# Altium schematic sheet sensors.SchDoc(sensors)
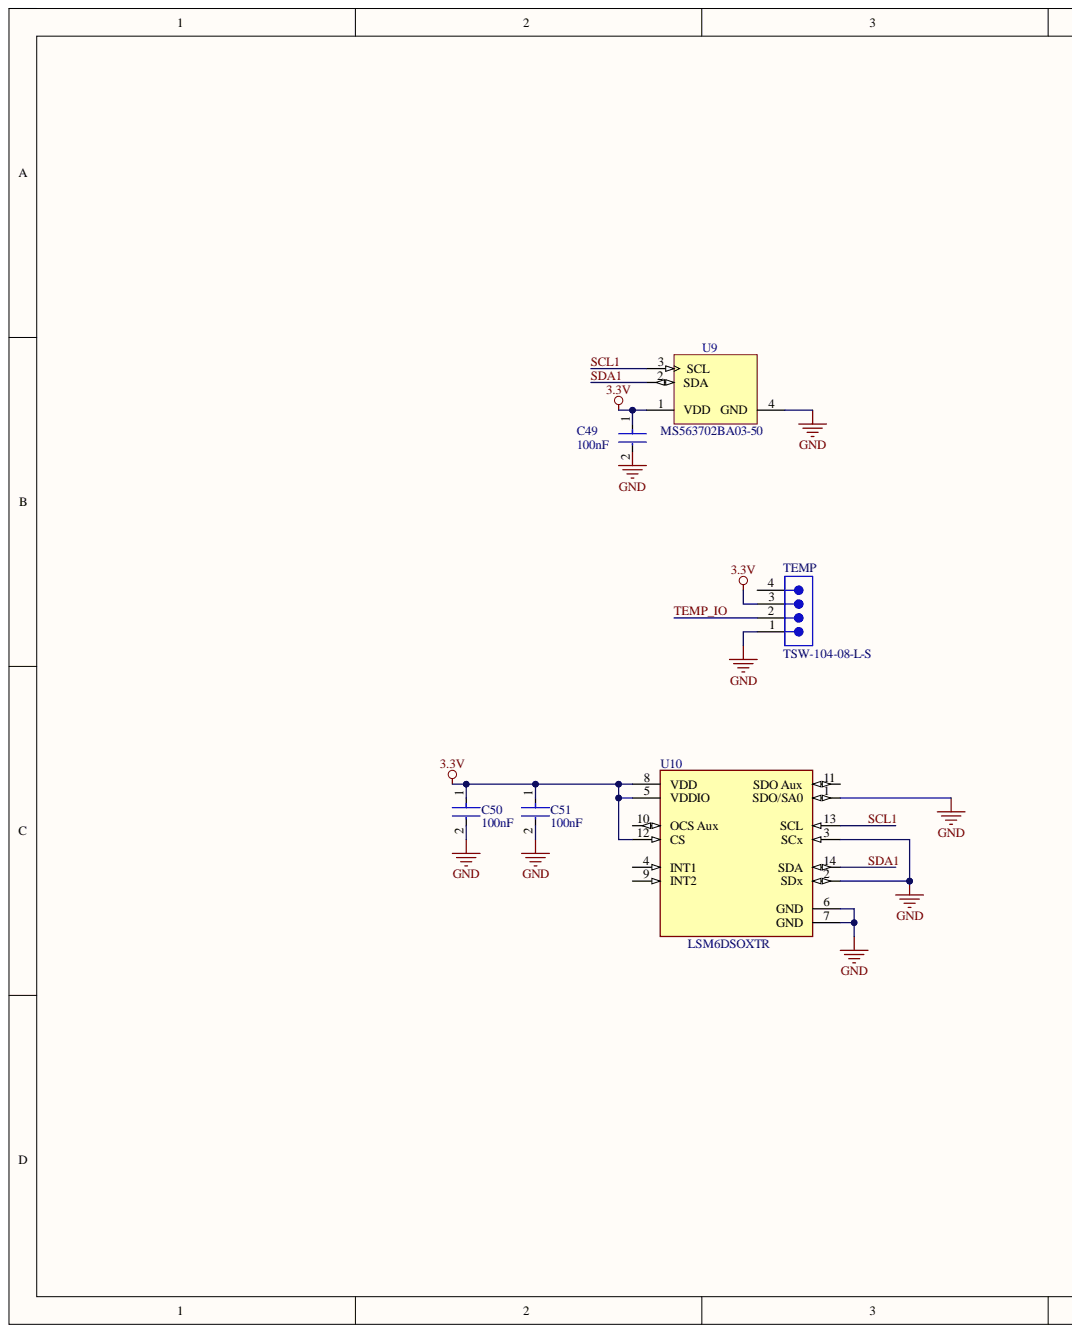
[diagram p0: sensors.SchDoc, left]
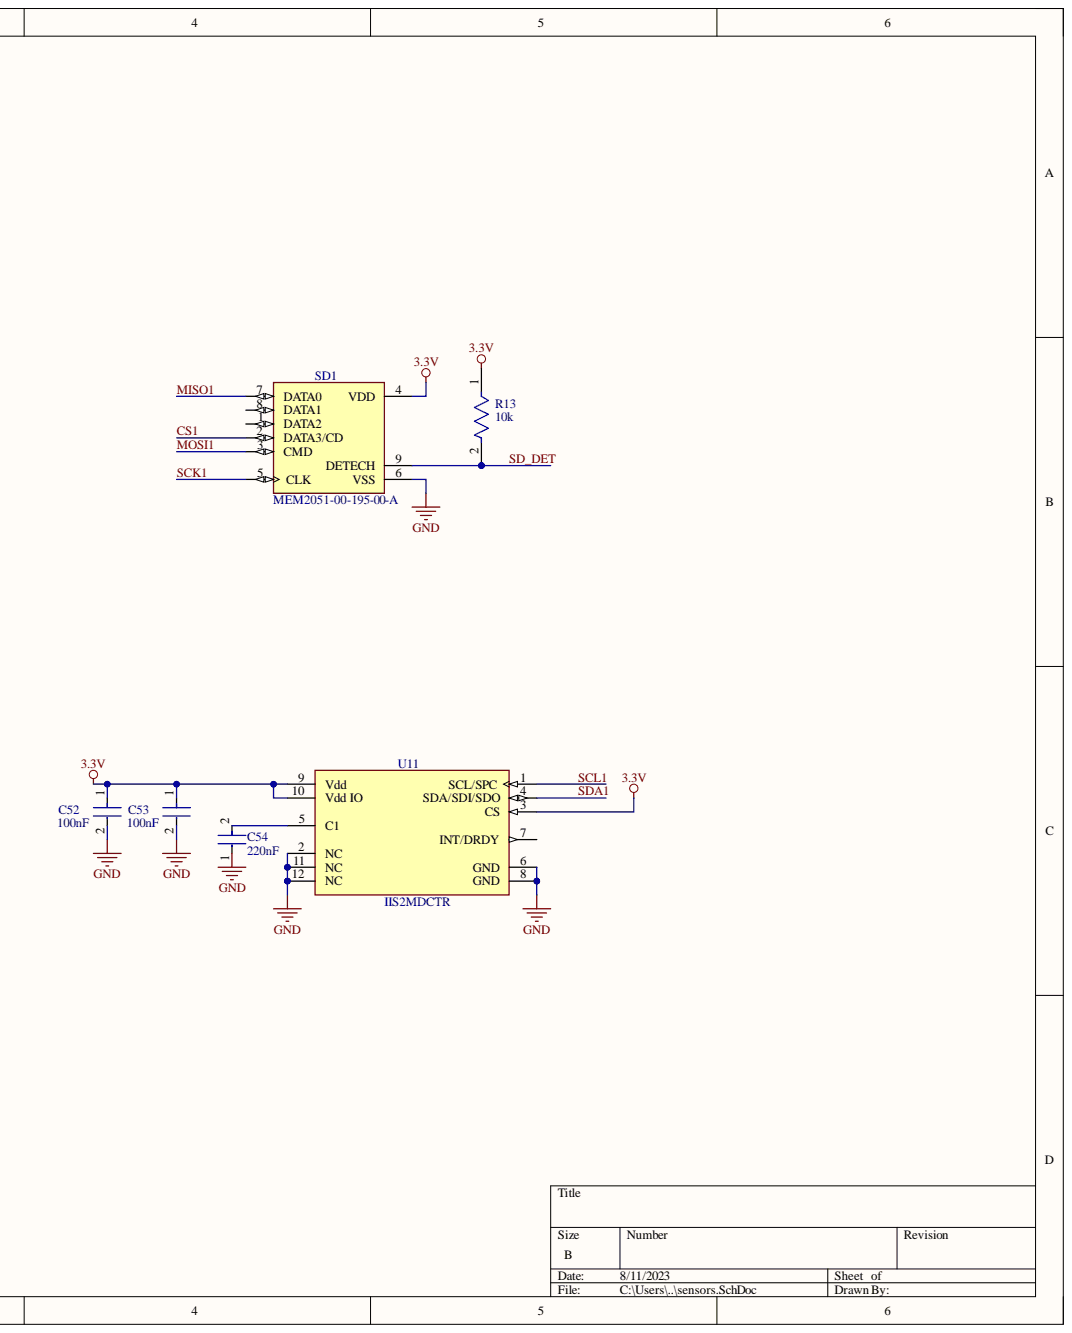
[diagram p1: sensors.SchDoc, right]

## Parts
C49  100nF  [FP-C0603C-CF-MFG]
C50  100nF  [FP-C0603C-CF-MFG]
C51  100nF  [FP-C0603C-CF-MFG]
C52  100nF  [FP-C0603C-CF-MFG]
C53  100nF  [FP-C0603C-CF-MFG]
C54  220nF  [FP-C1608-080-0_1-IPC_B]
R13  10k  [FP-RT0603-MFG]
SD1  MEM2051-00-195-00-A  [GCT_MEM2051-00-195-00-A]
TEMP  TSW-104-08-L-S  [SMTC-TSW-104-08-X-S]
U9  MS563702BA03-50  [TECO-MS563702BA03-50_V]
U10  LSM6DSOXTR  [FP-LGA-14L-DM00249496-MFG]
U11  IIS2MDCTR  [FP-LGA-12-DM00170568-MFG]

## Nets
3.3V: C49.1 C50.1 C51.1 C52.1 C53.1 R13.1 SD1.4(VDD) TEMP.3 U9.1(VDD) U10.5(VDDIO) U10.8(VDD) U10.12(CS) U11.3(CS) U11.9(Vdd) U11.10(Vdd_IO)
GND: C49.2 C50.2 C51.2 C52.2 C53.2 C54.1 SD1.6(VSS) TEMP.1 U9.4(GND) U10.1(SDO/SA0) U10.2(SDx) U10.3(SCx) U10.6(GND) U10.7(GND) U11.2(NC) U11.6(GND) U11.8(GND) U11.11(NC) U11.12(NC)
CS1: SD1.2(DATA3/CD)
MISO1: SD1.7(DATA0)
MOSI1: SD1.3(CMD)
SCK1: SD1.5(CLK)
SCL1: U9.3(SCL) U10.13(SCL) U11.1(SCL/SPC)
SD_DET: R13.2 SD1.9(DETECH)
SDA1: U9.2(SDA) U10.14(SDA) U11.4(SDA/SDI/SDO)
TEMP_IO: TEMP.2
NetC54_2: C54.2 U11.5(C1)

Not connected: SD1 1(DATA2) 8(DATA1); TEMP 4; U10 4(INT1) 9(INT2) 10(OCS_Aux) 11(SDO_Aux); U11 7(INT/DRDY)

## Library data of the parts
C49-C53 = CMP-2006-03061-2: CAP CER 0.1UF 50V X7R 0603; Manufacturer: KEMET; Manufacturer Part Number: C0603C104K5RACTU; Automotive Grade: Grade 1; Capacitance: 0.10 μF; Material: Ceramic; Temperature Coefficient: 30ppm/°C; Tolerance: 0.1; Value: 0.10 μF; Automotive: Yes; Category: Cap; Component Kind: Standard; ComponentLink2Description: Datasheet; ComponentLink2URL: https://api.kemet.com/component-edge/download/datasheet/C0603C100J5GACAUTO.pdf; Device Class L1: Passive Components; Device Class L2: Capacitors; Device Class L3: Ceramic Capacitors; Digikey Description: CAP CER 0.1UF 50V X7R 0603; Height: 0.87mm; Lead Free: Yes; Library Name: Altium Content Vault; Octopart: https://octopart.com/c0603c104k5ractu-kemet-139244; Package: C0603C-CF; RoHS: TRUE; Temperature: -55°C to +125°C; Temperature Characteristic: X7R; Temperature Range High: +125°C; Temperature Range Low: -55°C; Voltage Rating: 50 V
C54 = CMP-08246-006534-1: Multilayer Ceramic Capacitors 220nF ±10% 16V X7R SMD 0603; Dissipation Factor: 5%; Insulation Resistance: 10GR; Capacitance: 220nF; Case Code (Imperial): 0603; Case Code (Metric): 1608; Case/Package: 0603; Component Kind: Standard; Construction: Flat; Depth: 0.8mm; Dielectric: X7R; Dielectric Material: Ceramic; Height: 0.8mm; Lead Free: Lead Free; Length: 1.6mm; Library Name: Altium Content Vault; Max Operating Temperature: 125°C; Min Operating Temperature: -55°C; Mount: Surface Mount; Number of Pins: 2; Package Quantity: 1; Packaging: Tape and Reel; Radiation Hardening: No; REACH SVHC: No SVHC; Resistor Type: Ceramic; RoHS Compliant: Yes; Series: C; Termination: SMD/SMT; Thickness: 0.037inch; Tolerance: 10%; Voltage: 16V; Voltage Rating: 16V; Voltage Rating (DC): 16V; Weight: 7.1E-05oz; Width: 0.031inch
R13 = CMP-2000-04517-2: RES SMD 10K OHM 0.1% 1/10W 0603; Manufacturer: Yageo; Manufacturer Part Number: RT0603BRE0710KL; Power Rating: 0.1W; Resistance: 10KΩ; Temperature Coefficient: 50 ppm/°C; Tolerance: 0.001; Value: 10KΩ; Voltage Rating: 75V; Automotive: No; Category: Res; Component Kind: Standard; ComponentLink2Description: Datasheet; ComponentLink2URL: http://www.yageo.com/documents/recent/PYu-RT_1-to-0.01_RoHS_L_9.pdf; Composition: Thin Film; Device Class L1: Passive Components; Device Class L2: Resistors; Device Class L3: Chip SMD Resistors; Digikey Description: RES SMD 10K OHM 0.1% 1/10W 0603; Footprint Url: http://www.yageo.com/exep/pages/download/literatures/PYu-R_Mount_10.pdf; Height: 0.55mm; Ipc Land Pattern Name: RESC16080X45; Lead Free: Yes; Library Name: Altium Content Vault; Mouser Description: Res Thin Film 0603 10K Ohm 0.1% 1/10W ±50ppm/°C Molded SMD SMD Paper T/R; Octopart: https://octopart.com/rt0603bre0710kl-yageo-1273959; Package: RT0603; RoHS: TRUE; Series: RT; Temperature: -55°C to +155°C; Temperature Range High: +155°C; Temperature Range Low: -55°C
SD1 = MEM2051-00-195-00-A: Connector, Memory Card, Micro Sd, 8 Position, 1.1mm, Full Reel; MF: {'manufacturer': u'Global Connector Technology'}; Availability: Unavailable; Component Kind: Standard; MP: MEM2051-00-195-00-A; Package: None; Price: None
TEMP = CMP-1024-00358-1: 0.025" SQ Post Header, Through-hole, Vertical, -55 to 125 degC, 2.54 mm Pitch, 4-Pin, Male, RoHS; Manufacturer: Samtec; Pitch: 2.54 mm; Component Kind: Standard; ComponentLink1Description: Manufacturer URL; ComponentLink1URL: http://www.samtec.com; ComponentLink2Description: Datasheet; ComponentLink2URL: http://www.samtec.com/documents/webfiles/pdf/TSW_TH.PDF; ComponentLink3Description: Package Specification; ComponentLink3URL: http://www.samtec.com/documents/webfiles/cpdf/TSW-XXX-XX-XXX-X-XX-XXX-MKT.pdf; DatasheetVersion: F-214; Library Name: Altium Content Vault; Mounting Technology: Through-hole; Orientation: Vertical; PackageDescription: .025[0.64] SQ. Post Terminal Strip Assembly; PackageReference: TSW-104-08-X-S; PackageVersion: DM, 8/1988; PartNumber: TSW-104-08-L-S; RoHS: True; Temperature Range: -55 to 125 degC
U9 = CMP-2000-07509-1: Low Voltage Barometric Pressure Sensor, 1.5 to 3.6 V, -40 to 85 degC, 4-Pin SMD, RoHS, Tape and Reel; Manufacturer: TE Connectivity; Component Kind: Standard; Datasheet URL: http://www.te.com/commerce/DocumentDelivery/DDEController?Action=showdoc&DocId=Data+Sheet%7FMS5637-02BA03%7FB4%7Fpdf%7FEnglish%7FENG_DS_MS5637-02BA03_B4.pdf%7FMS563702BA03-50; Datasheet Version: 06/2017; Lead Free: LeadFree; Library Name: Altium Content Vault; Manufacturer URL: http://www.te.com/; Mounting Technology: SurfaceMount; Package Description: 4-Pin Surface Mount Device, Body 3 x 3 mm, Pitch 2 mm; Package Reference: MS563702BA03-50; RoHSCompliant: false
U10 = CMP-12177-000010-1: INEMO INERTIAL MODULE: 3D ACCELE; Manufacturer: STMicroelectronics; Accel Range: 2/4/8/16g; Accel Sensitivity: 0.061/0.122/0.244/0.488mV/g; Gyro Range: 125/250/500/1000/2000°/s; Gyro Sensitivity: 4.375/8.75/17.5/35/70LSB/°/s; Max Supply Voltage: 3.6V; Min Supply Voltage: 1.62V; Operating Supply Current: 0.55mA; Sensor Output: I2C,SPI,Other; Sensor Type: Temperature,Accelerometer,Gyroscope; Automotive: No; Category: IC; Component Kind: Standard; ComponentLink2Description: Datasheet; ComponentLink2URL: https://www.st.com/resource/en/datasheet/lsm6dsox.pdf; Device Class L1: Sensors; Device Class L2: Motion Sensors; Device Class L3: Inertial Measurement Units (IMUs); Digikey Description: INEMO INERTIAL MODULE: 3D ACCELE; Footprint Url: https://www.st.com/content/ccc/resource/technical/document/technical_note/73/17/9c/16/dd/96/4a/01/CD00134799.pdf/files/CD00134799.pdf/jcr:content/translations/en.CD00134799.pdf; Height: 0.86mm; Lead Free: Yes; Library Name: Altium Content Vault; Manufacturer Part Number: LSM6DSOXTR; Mouser Description: LSM6DSOX: 3.6 V 3 Axis Accelerometer Gyroscope iNEMO Inertial Module - LGA-14L; Octopart: https://octopart.com/lsm6dsoxtr-stmicroelectronics-98102600; Package: LGA-14L-DM00249496; RoHS: TRUE; Temperature: -40°C to +85°C; Temperature Range High: +85°C; Temperature Range Low: -40°C
U11 = CMP-12173-000003-1: SENSOR MR I2C/SPI 12LGA; Axis: X, Y, Z; Output Type: SPI; Resolution: 16b; Sensing Method: Magnetoresistive; Case/Package: LGA; Component Kind: Standard; Library Name: Altium Content Vault; Max Operating Temperature: 85°C; Min Operating Temperature: -40°C; Number of Pins: 12; Packaging: Cut Tape; RoHS Compliant: Yes
